# Altium schematic sheet Main.SchDoc
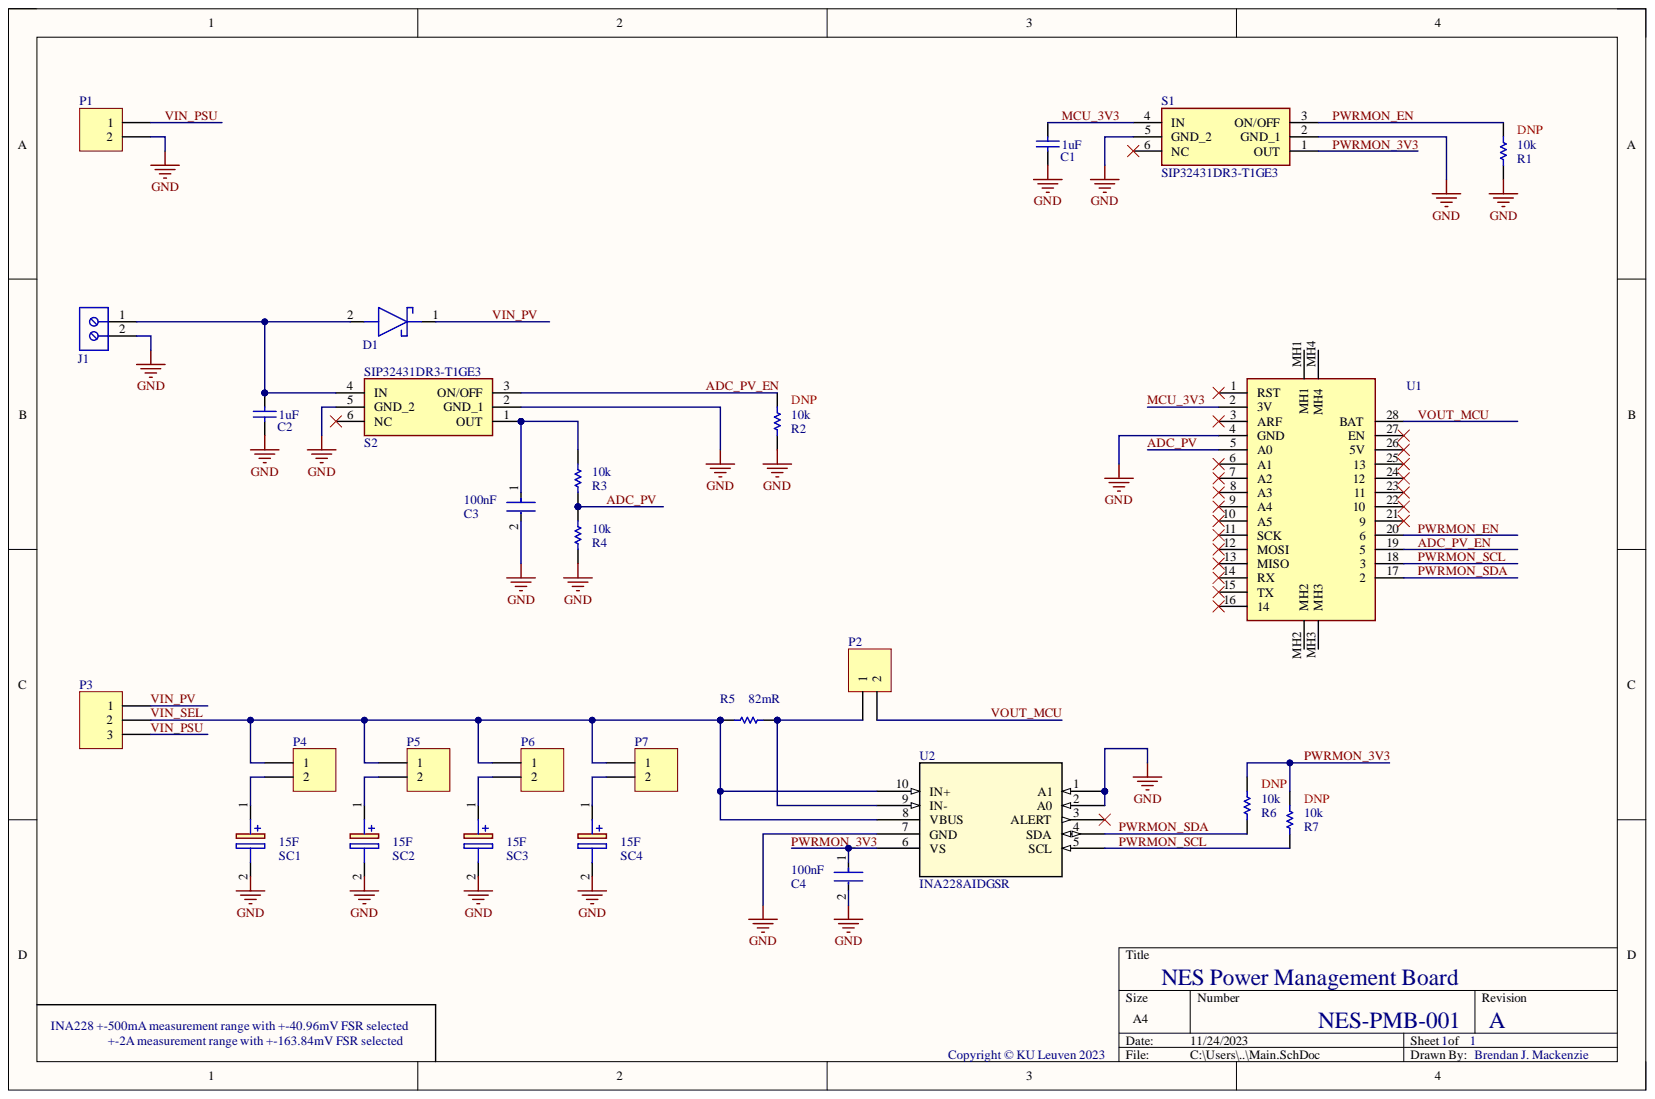
[diagram p0: Main.SchDoc]

## Parts
C1  1uF  [CAPC2013X145X50ML20T25]
C2  1uF  [CAPC2013X145X50ML20T25]
C3  100nF  [FP-CC0805-0_85-IPC_A]
C4  100nF  [FP-CC0805-0_85-IPC_A]
D1  Diode_LowVf_EHFrontend  [NexPeriaLowVF_PMEG1020EA]  (value not drawn)
J1  Screw Terminal  [ScrewTerminal]  (value not drawn)
P1  Pwr  [HDR1X2]  (value not drawn)
P2  Pwr  [HDR1X2]  (value not drawn)
P3  Header 3  [HDR1X3]  (value not drawn)
P4  Pwr  [HDR1X2]  (value not drawn)
P5  Pwr  [HDR1X2]  (value not drawn)
P6  Pwr  [HDR1X2]  (value not drawn)
P7  Pwr  [HDR1X2]  (value not drawn)
R1  10k  [RESC2013X60X40LL20T25_A]  (no BOM)
R2  10k  [RESC2013X60X40LL20T25_A]  (no BOM)
R3  10k  [RESC2013X60X40LL20T25_A]
R4  10k  [RESC2013X60X40LL20T25_A]
R5  82mR  [RES1206IMP-4TERM]
R6  10k  [RESC2013X60X40LL20T25_A]  (no BOM)
R7  10k  [RESC2013X60X40LL20T25_A]  (no BOM)
S1  SIP32431DR3-T1GE3  [LoadSW-SOT65P210X110-6N]
S2  SIP32431DR3-T1GE3  [LoadSW-SOT65P210X110-6N]
SC1  15F  [SuperCap 15F 5.5V Carbon Aerogel]
SC2  15F  [SuperCap 15F 5.5V Carbon Aerogel]
SC3  15F  [SuperCap 15F 5.5V Carbon Aerogel]
SC4  15F  [SuperCap 15F 5.5V Carbon Aerogel]
U1  BSFrance ATMega32U4 LoRa Board  [BSFrance ATMega32U4 LoRa Feather]  (value not drawn)
U2  INA228AIDGSR  [VSSOP10_DGS_TEX]

## Nets
GND: C1.1 C2.1 C3.2 C4.2 J1.2 P1.2 R1.1 R2.1 R4.1 S1.2(GND_1) S1.5(GND_2) S2.2(GND_1) S2.5(GND_2) SC1.2 SC2.2 SC3.2 SC4.2 U1.4(GND) U2.1(A1) U2.2(A0) U2.7(GND)
ADC_PV: R3.1 R4.2 U1.5(A0)
ADC_PV_EN: R2.2 S2.3(ON/OFF) U1.19
MCU_3V3: C1.2 S1.4(IN) U1.2(3V)
PWRMON_3V3: C4.1 R6.2 R7.2 S1.1(OUT) U2.6(VS)
PWRMON_EN: R1.2 S1.3(ON/OFF) U1.20
PWRMON_SCL: R7.1 U1.18 U2.5(SCL)
PWRMON_SDA: R6.1 U1.17 U2.4(SDA)
VIN_PSU: P1.1 P3.3
VIN_PV: D1.1 P3.1
VIN_SEL: P3.2 P4.1 P5.1 P6.1 P7.1 R5.1 U2.8(VBUS) U2.10(IN+)
VOUT_MCU: P2.2 U1.28(BAT)
NetC2_2: C2.2 D1.2 J1.1 S2.4(IN)
NetC3_1: C3.1 R3.2 S2.1(OUT)
NetP2_1: P2.1 R5.2 U2.9(IN-)
NetP4_2: P4.2 SC1.1
NetP5_2: P5.2 SC2.1
NetP6_2: P6.2 SC3.1
NetP7_2: P7.2 SC4.1

Not connected: S1 6(NC); S2 6(NC); U1 1(RST) 3(ARF) 6(A1) 7(A2) 8(A3) 9(A4) 10(A5) 11(SCK) 12(MOSI) 13(MISO) 14(RX) 15(TX) 16 21 22 23 24 25 26(5V) 27(EN) MH1 MH2 MH3 MH4; U2 3(ALERT)

## Library data of the parts
C1 C2 = Cap_1uF_0805: Cap Ceramic 1uF 16V X5R 10% SMD 0805 85C Plastic T/R, C0805C105K4PACTU; Manufacturer: KEMET; Dissipation Factor: 5%; Capacitance: 1uF; Case Code (Imperial): 0805; Case Code (Metric): 2012; Case/Package: 0805; Category: Ceramic Capacitors; Component Kind: Standard; ComponentLink1Description: http://www.farnell.com/datasheets/2745930.pdf?src-supplier=Farnell; ComponentLink1URL: http://www.farnell.com/datasheets/2745930.pdf?src-supplier=Farnell; ComponentLink2Description: http://uk.rs-online.com/webdocs/13da/0900766b813daa0f.pdf?src-supplier=RSComponents; ComponentLink2URL: http://uk.rs-online.com/webdocs/13da/0900766b813daa0f.pdf?src-supplier=RSComponents; ComponentLink3Description: http://uk.rs-online.com/webdocs/13da/0900766b813daa10.pdf?src-supplier=RSComponents; ComponentLink3URL: http://uk.rs-online.com/webdocs/13da/0900766b813daa10.pdf?src-supplier=RSComponents; ComponentLink4Description: http://components.arrow.com/part/dataview/43037300S8313636N7429?src-supplier=Arrow+Electronics; ComponentLink4URL: http://components.arrow.com/part/dataview/43037300S8313636N7429?src-supplier=Arrow+Electronics; ComponentLink5Description: http://components.arrow.com/part/dataview/0323_00324725?src-supplier=Arrow+Europe; ComponentLink5URL: http://components.arrow.com/part/dataview/0323_00324725?src-supplier=Arrow+Europe; ComponentLink6Description: https://api.kemet.com/component-edge/download/datasheet/C0805C105K4PAC.pdf?src-supplier=Digi-Key; ComponentLink6URL: https://api.kemet.com/component-edge/download/datasheet/C0805C105K4PAC.pdf?src-supplier=Digi-Key; ComponentLink7Description: http://www.farnell.com/datasheets/1824493.pdf?src-supplier=Newark; ComponentLink7URL: http://www.farnell.com/datasheets/1824493.pdf?src-supplier=Newark; ComponentLink8Description: https://search.kemet.com/component-edge/download/datasheet/C0805C105K4PAC.pdf?src-supplier=Allied+Electronics; ComponentLink8URL: https://search.kemet.com/component-edge/download/datasheet/C0805C105K4PAC.pdf?src-supplier=Allied+Electronics; ComponentLink9Description: https://search.kemet.com/component-edge/download/datasheet/C0805C105K4PAC.pdf?src-supplier=Mouser; ComponentLink9URL: https://search.kemet.com/component-edge/download/datasheet/C0805C105K4PAC.pdf?src-supplier=Mouser; ComponentLink10Description: http://www.farnell.com/datasheets/2745930.pdf?src-supplier=Element14; ComponentLink10URL: http://www.farnell.com/datasheets/2745930.pdf?src-supplier=Element14; ComponentLink11Description: https://search.kemet.com/component-edge/download/datasheet/C0805C105K4PAC.pdf?src-supplier=Avnet; ComponentLink11URL: https://search.kemet.com/component-edge/download/datasheet/C0805C105K4PAC.pdf?src-supplier=Avnet; ComponentLink12Description: http://www.kemet.com/Lists/ProductCatalog/Attachments/19/KEM_C1006_X5R_SMD.pdf?src-supplier=Avnet; ComponentLink12URL: http://www.kemet.com/Lists/ProductCatalog/Attachments/19/KEM_C1006_X5R_SMD.pdf?src-supplier=Avnet; ComponentLink13Description: http://www.farnell.com/datasheets/2745930.pdf?src-supplier=Newark; ComponentLink13URL: http://www.farnell.com/datasheets/2745930.pdf?src-supplier=Newark; ComponentLink14Description: https://www.verical.com/server-webapp/html/getDatasheet?partID=127986&src-supplier=Verical; ComponentLink14URL: https://www.verical.com/server-webapp/html/getDatasheet?partID=127986&src-supplier=Verical; ComponentLink15Description: https://search.kemet.com/component-edge/download/datasheet/C0805C105K4PAC.pdf?src-supplier=TTI; ComponentLink15URL: https://search.kemet.com/component-edge/download/datasheet/C0805C105K4PAC.pdf?src-supplier=TTI; Construction: Flat; Depth: 1.25mm; Dielectric: X5R; Dielectric Material: Ceramic; Height: 1.25mm; Lead Free: Lead Free; Length: 2mm; Manufacturer Part Number: C0805C105K4PACTU; Max Operating Temperature: 85°C; Min Operating Temperature: -55°C; Mount: Surface Mount,PCB; Package Quantity: 1; Packaging: Tape and Reel; Pricing 1: 1=0.45000, 10=0.26100, 50=0.26100, 100=0.15700, 1000=0.12900, 10000=0.09500 (USD); Radiation Hardening: No; REACH SVHC: No SVHC; RoHS: Yes; RoHS Compliant: Yes; Series: C0805C; Stock 1: 5918; Supplier 1: Mouser; Supplier Part Number 1: 80-C0805C105K4P; Termination: SMD/SMT; Thickness: 0.055inch; Tolerance: 10%; Voltage: 16V; Voltage Rating: 16V; Voltage Rating (DC): 16V; Width: 0.049inch; Library Name: NESSchLib.SchLib
C3 C4 = 100nF_25v_0805: CAP CER 0.1UF 25V X7R 0805; Manufacturer: Kyocera AVX; Manufacturer Part Number: 08053C104KAT2A; Dissipation Factor: 3%; Insulation Resistance: 100GR; Tolerance: 10%; Capacitance: 100nF; Case Code (Imperial): 0805; Case Code (Metric): 2012; Case/Package: 0805; Category: Ceramic Capacitors; Component Kind: Standard; ComponentLink1Description: https://datasheet.ciiva.com/11786/0900766b80ed4be2-11786193.pdf?src-supplier=RSComponents; ComponentLink1URL: https://datasheet.ciiva.com/11786/0900766b80ed4be2-11786193.pdf?src-supplier=RSComponents; ComponentLink2Description: https://datasheet.ciiva.com/2987/cx7r-2987955.pdf?src-supplier=Avnet; ComponentLink2URL: https://datasheet.ciiva.com/2987/cx7r-2987955.pdf?src-supplier=Avnet; ComponentLink3Description: https://datasheet.ciiva.com/7811/cx7r-7811990.pdf?src-supplier=Element14; ComponentLink3URL: https://datasheet.ciiva.com/7811/cx7r-7811990.pdf?src-supplier=Element14; ComponentLink4Description: https://datasheet.ciiva.com/14596/70001075-14596006.pdf?src-supplier=Allied+Electronics; ComponentLink4URL: https://datasheet.ciiva.com/14596/70001075-14596006.pdf?src-supplier=Allied+Electronics; ComponentLink5Description: https://datasheet.ciiva.com/16536/cx7r-16536998.pdf?src-supplier=Avnet+Asia; ComponentLink5URL: https://datasheet.ciiva.com/16536/cx7r-16536998.pdf?src-supplier=Avnet+Asia; ComponentLink6Description: https://datasheet.ciiva.com/7811/cx7r-7811991.pdf?src-supplier=Element14; ComponentLink6URL: https://datasheet.ciiva.com/7811/cx7r-7811991.pdf?src-supplier=Element14; ComponentLink7Description: https://datasheet.ciiva.com/7811/cx7r-7811992.pdf?src-supplier=Element14; ComponentLink7URL: https://datasheet.ciiva.com/7811/cx7r-7811992.pdf?src-supplier=Element14; ComponentLink8Description: https://datasheet.ciiva.com/5416/cx7r-5416971.pdf?src-supplier=Mouser; ComponentLink8URL: https://datasheet.ciiva.com/5416/cx7r-5416971.pdf?src-supplier=Mouser; ComponentLink9Description: https://datasheet.ciiva.com/11462/cx7r-11462779.pdf?src-supplier=Avnet; ComponentLink9URL: https://datasheet.ciiva.com/11462/cx7r-11462779.pdf?src-supplier=Avnet; ComponentLink10Description: https://datasheet.ciiva.com/150/cx7r-150317.pdf?src-supplier=Digi-Key; ComponentLink10URL: https://datasheet.ciiva.com/150/cx7r-150317.pdf?src-supplier=Digi-Key; ComponentLink11Description: https://datasheet.ciiva.com/150/cx7r-150318.pdf?src-supplier=Digi-Key; ComponentLink11URL: https://datasheet.ciiva.com/150/cx7r-150318.pdf?src-supplier=Digi-Key; ComponentLink12Description: https://datasheet.ciiva.com/150/cx7r-150319.pdf?src-supplier=Digi-Key; ComponentLink12URL: https://datasheet.ciiva.com/150/cx7r-150319.pdf?src-supplier=Digi-Key; Construction: Flat; Contact Plating: Tin,Matte; Depth: 1.25mm; Dielectric: X7R; Height: 0.94mm; Lead Free: Lead Free; Length: 2.01mm; Max Operating Temperature: 125°C; Min Operating Temperature: -55°C; Mount: Surface Mount,PCB; Number of Pins: 2; Package Quantity: 1; Packaging: Tape and Reel; Pricing 1: 1=0.10000, 10=0.07000, 50=0.03820, 100=0.03120, 500=0.02226, 1000=0.01749 (USD); Radiation Hardening: No; REACH SVHC: No SVHC; RoHS: Yes; RoHS Compliant: Yes; Series: -; Stock 1: 1380304; Supplier 1: Digi-Key; Supplier Part Number 1: 478-3755-1-ND; Termination: SMD/SMT; Thickness: 0.037inch; Voltage: 25V; Voltage Rating: 25V; Voltage Rating (DC): 25V; Width: 0.049inch; Library Name: NESSchLib.SchLib
D1 = Diode_LowVf_EHFrontend: PMEG1020EA,115, DIODE SCHOTTKY 10V 2A SOD323; Manufacturer: Nexperia; Category: Rectifier Diodes; Component Kind: Standard; ComponentLink1Description: https://assets.nexperia.com/documents/data-sheet/PMEG1020EA.pdf?src-supplier=Digi-Key; ComponentLink1URL: https://assets.nexperia.com/documents/data-sheet/PMEG1020EA.pdf?src-supplier=Digi-Key; ComponentLink2Description: http://www.farnell.com/datasheets/701039.pdf?src-supplier=Element14; ComponentLink2URL: http://www.farnell.com/datasheets/701039.pdf?src-supplier=Element14; Pricing 1: 5=0.55900, 10=0.42400, 100=0.26400, 500=0.18100 (EUR); RoHS: Yes; RoHS Compliant: Yes; Stock 1: 6405; Supplier 1: Farnell; Library Name: NESSchLib.SchLib
J1 = Screw Terminal: Serie 2141S - 3.50 mm Horizontal Entry Modular with Rising Cage Clamp WR-TBL, 2 pin; Manufacturer: Wurth Electronics; Manufacturer Part Number: 691214110002S; Category: Terminal Blocks; Component Kind: Standard; Pricing 1: 1=1.14000, 10=1.11900, 50=1.03700, 100=0.95300, 500=0.87800 (USD); RoHS: No; Stock 1: 1923; Supplier 1: Digi-Key; Supplier Part Number 1: 732-691214110002S-ND; Library Name: NESSchLib.SchLib
P1 P2 P4-P7 = Header 2: Header, 2-Pin; Component Kind: Standard; LatestRevisionDate: 17-Jul-2002; LatestRevisionNote: Re-released for DXP Platform.; Signal Integrity: Connector; Library Name: NESSchLib.SchLib; Publisher: Altium Limited
P3 = Header 3: Header, 3-Pin; Component Kind: Standard; LatestRevisionDate: 17-Jul-2002; LatestRevisionNote: Re-released for DXP Platform.; Signal Integrity: Connector; Library Name: NESSchLib.SchLib; Publisher: Altium Limited
R1-R4 R6 R7 = Resistor_10K_0805: RESISTOR, 0805, 10K, FULL REEL; Manufacturer: Panasonic; Manufacturer Part Number: ERA-6AEB103V; Max Power Dissipation: 125mW; Resistance: 10kR; Temperature Coefficient: 25ppm/°C; Tolerance: 0.1%; Voltage Rating: 100V; Case Code (Imperial): 0805; Case Code (Metric): 2012; Case/Package: 0805; Category: Chip SMD Resistors; ComponentLink1Description: https://datasheet.ciiva.com/11810/0900766b80beefcc-11810466.pdf?src-supplier=RSComponents; ComponentLink1URL: https://datasheet.ciiva.com/11810/0900766b80beefcc-11810466.pdf?src-supplier=RSComponents; ComponentLink2Description: https://datasheet.ciiva.com/26335/453339-da-01-en-widerstand-0805-era-26335701.pdf?src-supplier=Conrad; ComponentLink2URL: https://datasheet.ciiva.com/26335/453339-da-01-en-widerstand-0805-era-26335701.pdf?src-supplier=Conrad; ComponentLink3Description: https://datasheet.ciiva.com/43455/485442-43455269.pdf?src-supplier=Farnell; ComponentLink3URL: https://datasheet.ciiva.com/43455/485442-43455269.pdf?src-supplier=Farnell; ComponentLink4Description: https://datasheet.ciiva.com/28513/485442-28513862.pdf?src-supplier=Element14; ComponentLink4URL: https://datasheet.ciiva.com/28513/485442-28513862.pdf?src-supplier=Element14; ComponentLink5Description: https://datasheet.ciiva.com/24072/aoa0000ce26-197354-24072839.pdf?src-supplier=Mouser; ComponentLink5URL: https://datasheet.ciiva.com/24072/aoa0000ce26-197354-24072839.pdf?src-supplier=Mouser; ComponentLink6Description: https://datasheet.ciiva.com/48529/era-eng-tds-48529783.pdf?src-supplier=Distrelec; ComponentLink6URL: https://datasheet.ciiva.com/48529/era-eng-tds-48529783.pdf?src-supplier=Distrelec; ComponentLink7Description: https://datasheet.ciiva.com/48531/aoa0000ce26-48531095.pdf?src-supplier=Avnet; ComponentLink7URL: https://datasheet.ciiva.com/48531/aoa0000ce26-48531095.pdf?src-supplier=Avnet; ComponentLink8Description: https://datasheet.ciiva.com/16200/aoa0000ce26-16200216.pdf?src-supplier=Future+Electronics; ComponentLink8URL: https://datasheet.ciiva.com/16200/aoa0000ce26-16200216.pdf?src-supplier=Future+Electronics; ComponentLink9Description: https://datasheet.ciiva.com/16776/70068849-16776328.pdf?src-supplier=Allied+Electronics; ComponentLink9URL: https://datasheet.ciiva.com/16776/70068849-16776328.pdf?src-supplier=Allied+Electronics; ComponentLink10Description: https://datasheet.ciiva.com/16762/70068849-16762828.pdf?src-supplier=Allied+Electronics; ComponentLink10URL: https://datasheet.ciiva.com/16762/70068849-16762828.pdf?src-supplier=Allied+Electronics; ComponentLink11Description: https://datasheet.ciiva.com/7830/485442-7830637.pdf?src-supplier=Element14; ComponentLink11URL: https://datasheet.ciiva.com/7830/485442-7830637.pdf?src-supplier=Element14; ComponentLink12Description: https://datasheet.ciiva.com/7830/485442-7830638.pdf?src-supplier=Element14; ComponentLink12URL: https://datasheet.ciiva.com/7830/485442-7830638.pdf?src-supplier=Element14; ComponentLink13Description: https://datasheet.ciiva.com/7830/485442-7830639.pdf?src-supplier=Element14; ComponentLink13URL: https://datasheet.ciiva.com/7830/485442-7830639.pdf?src-supplier=Element14; ComponentLink14Description: https://datasheet.ciiva.com/22785/485442-22785935.pdf?src-supplier=Farnell; ComponentLink14URL: https://datasheet.ciiva.com/22785/485442-22785935.pdf?src-supplier=Farnell; Contact Plating: Tin; Depth: 1.25mm; Height: 0.5mm; Lead Free: Lead Free; Length: 2mm; Max Operating Temperature: 155°C; Military Standard: Not; Min Operating Temperature: -55°C; Mount: Surface Mount; Number of Pins: 2; Number of Terminations: 2; Package Quantity: 5000; Packaging: Tape and Reel; Power Rating: 125mW; Pricing 1: 1=0.31000, 10=0.26200, 100=0.10230, 1000=0.04284, 2500=0.03927 (USD); Radiation Hardening: No; REACH SVHC: No SVHC; Resistor Type: Thin Film,Metal Film; RoHS: Yes; Stock 1: 70295; Supplier 1: Digi-Key; Supplier Part Number 1: P10KDACT-ND; Termination: SMD/SMT; Voltage Rating (DC): 100V; Width: 1.25mm; Working Voltage: 100V; Library Name: NESSchLib.SchLib
  R3 R4: Component Kind: Standard
R5 = Resistor_82mR_1206_4TERM: Component Kind: Standard; Supplier 1: Digi-Key; Supplier Part Number 1: 18-L4CL1206LR082DNRCT-ND; Library Name: NESSchLib.SchLib
S1 S2 = SIP32431DR3-T1GE3: Power Switch Hi Side 1A 6-Pin SC-70 T/R; Manufacturer: Vishay Siliconix; Max Output Current: 3A; Max Power Dissipation: 250mW; Max Supply Voltage: 5.5V; Min Supply Voltage: 1.1V; Number of Outputs: 1; On-State Resistance: 147mR; Turn-Off Delay Time: 10us; Turn-On Delay Time: 40us; Case/Package: SC; Category: Hot Swap Controllers; Component Kind: Standard; ComponentLink1Description: https://datasheet.ciiva.com/1954/sip32431-1954880.pdf?src-supplier=Digi-Key; ComponentLink1URL: https://datasheet.ciiva.com/1954/sip32431-1954880.pdf?src-supplier=Digi-Key; ComponentLink2Description: https://datasheet.ciiva.com/4923/sip32431-109448-4923460.pdf?src-supplier=Mouser; ComponentLink2URL: https://datasheet.ciiva.com/4923/sip32431-109448-4923460.pdf?src-supplier=Mouser; ComponentLink3Description: https://datasheet.ciiva.com/26931/getdatasheetpartid-726165-26931257.pdf?src-supplier=Verical; ComponentLink3URL: https://datasheet.ciiva.com/26931/getdatasheetpartid-726165-26931257.pdf?src-supplier=Verical; Lead Free: Lead Free; Manufacturer Part Number: SIP32431DR3-T1GE3; Max Operating Temperature: 85°C; Min Operating Temperature: -40°C; Mount: Surface Mount; Number of Pins: 6; Output Current: 1A; Output Current per Channel: 1A; Package Quantity: 1; Packaging: Tape and Reel; Power Dissipation: 250mW; Pricing 1: 1=0.60000, 10=0.52500, 25=0.49280, 100=0.40230, 250=0.37364, 500=0.31800, 1000=0.25440 (USD); Radiation Hardening: No; REACH SVHC: Unknown; RoHS: Yes; RoHS Compliant: Yes; Series: -; Stock 1: 62080; Supplier 1: Digi-Key; Supplier Part Number 1: SIP32431DR3-T1GE3CT-ND; Weight: 0.000988oz; Library Name: NESSchLib.SchLib
SC1-SC4 = SuperCapacitor 15F 5.5V: Cap 15F 5.5V -10% to 30% Cylindrical Can 23.7mm -40 to 65°C Bulk; Category: Capacitors; Component Kind: Standard; RoHS: Yes; Stock 1: 29; Library Name: NESSchLib.SchLib
U2 = INA228AIDGSR: No Description Available; Mfr_Name: Texas Instruments; Component Kind: Standard; Manufacturer_Part_Number: INA228AIDGSR; RefDes: RefDes; Type: DEV; Copyright: Copyright (C) 2023 Ultra Librarian. All rights reserved.; Library Name: NESSchLib.SchLib
U1 = BSFrance ATMega32U4 LoRa Board: Component Kind: Standard; Library Name: NESSchLib.SchLib
